# Altium schematic sheet Controller_Sensor_Section.SchDoc
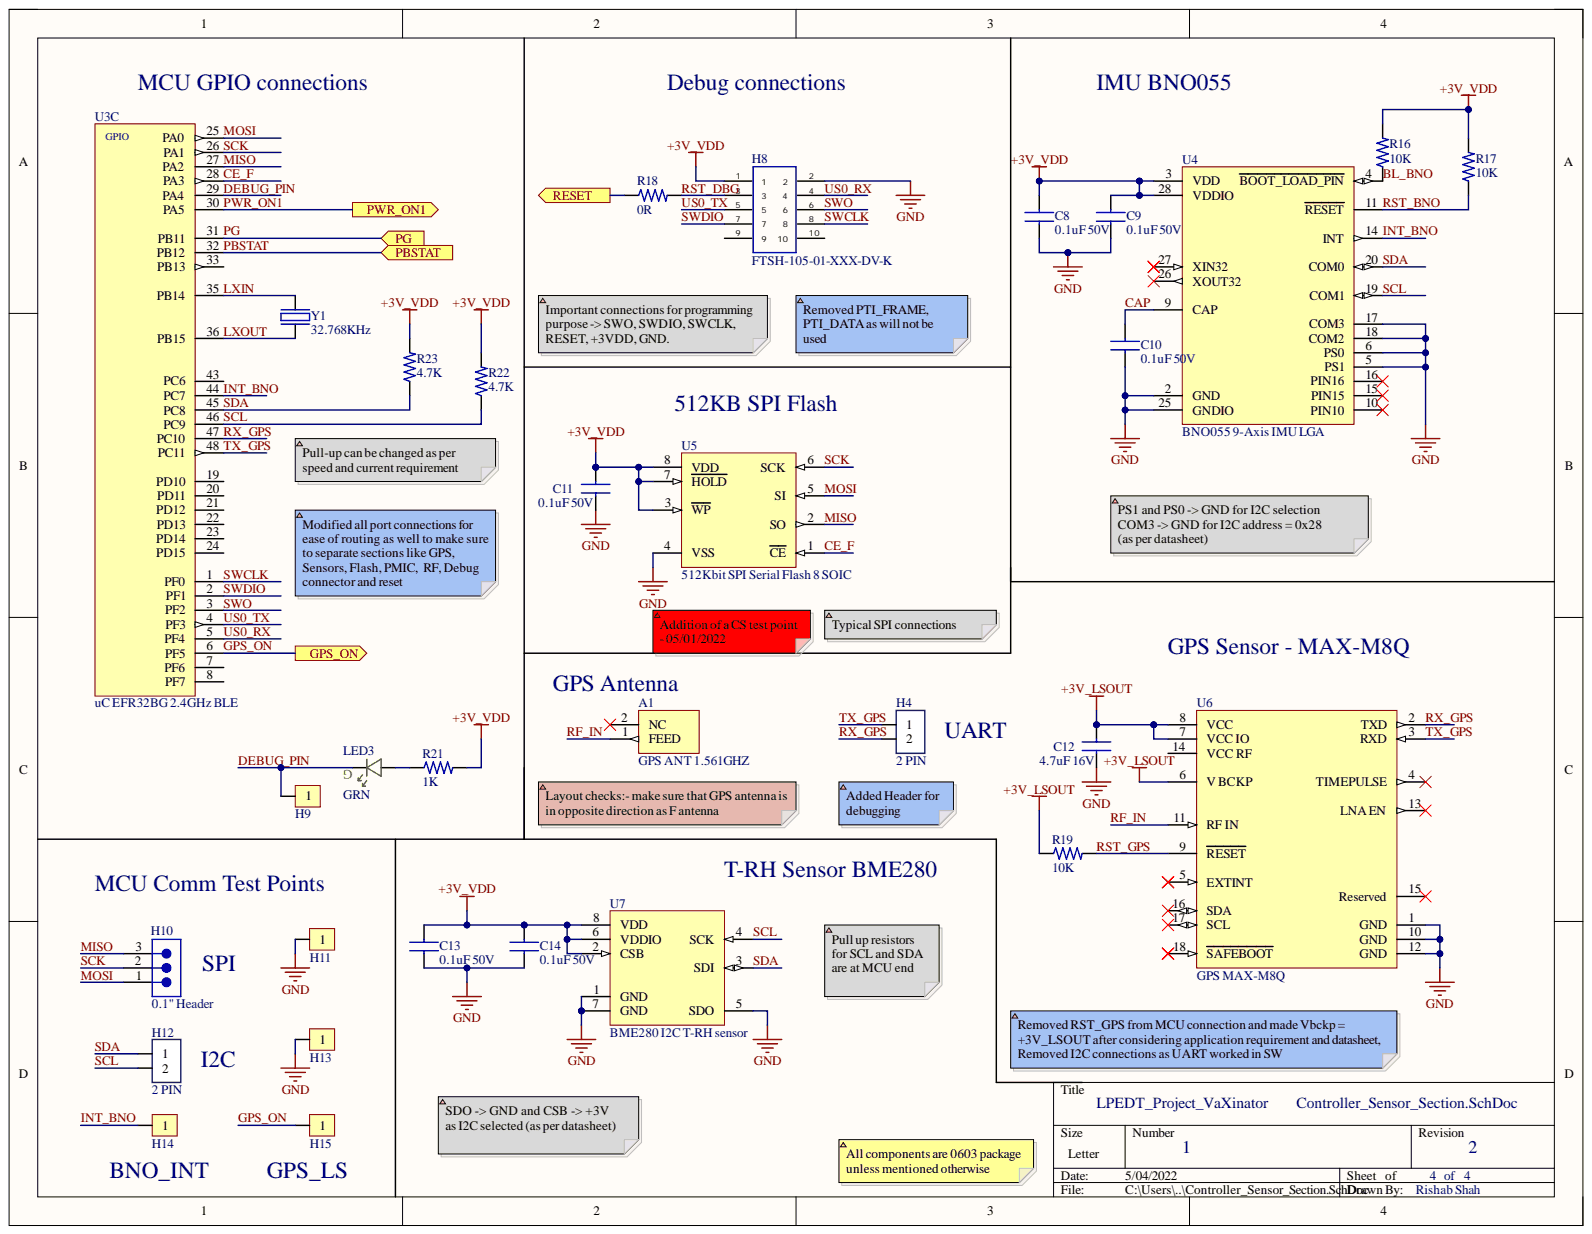
[diagram p0: Controller_Sensor_Section.SchDoc]

## Parts
A1  GPS ANT 1.561GHZ  [GPS_ANT]
C8  0.1uF 50V  [CAP_0603_1608X09N]
C9  0.1uF 50V  Voltage Rating: 50V  [CAP_0603_1608X09N]
C10  0.1uF 50V  [CAP_0603_1608X09N]
C11  0.1uF 50V  Voltage Rating: 50V  [CAP_0603_1608X09N]
C12  4.7uF 16V  Capacitance: 4.7uF  Voltage Rating: 16V  [CAP_0603_1608X09N]
C13  0.1uF 50V  [CAP_0603_1608X09N]
C14  0.1uF 50V  Voltage Rating: 50V  [CAP_0603_1608X09N]
H4  2 PIN  [2_PIN_100MIL]
H8  FTSH-105-01-XXX-DV-K  [FTSH-105-01-XXX-DV-K]
H9  1 pin header  [1_PIN_100MIL]  (value not drawn)
H10  0.1" Header  [3-PIN_MALE_HEADER_01-IN]
H11  1 pin header  [1_PIN_100MIL]  (value not drawn)
H12  2 PIN  [2_PIN_100MIL]
H13  1 pin header  [1_PIN_100MIL]  (value not drawn)
H14  1 pin header  [1_PIN_100MIL]  (value not drawn)
H15  1 pin header  [1_PIN_100MIL]  (value not drawn)
LED3  GRN  [LED_0603_GRN_WL-SMCW]
R16  10K  [RES_0603_1608X06N]
R17  10K  [RES_0603_1608X06N]
R18  0R  [RES_0603_1608X06N]
R19  10K  [RES_0603_1608X06N]
R21  1K  [RES_0603_1608X06N]
R22  4.7K  [RES_0603_1608X06N]
R23  4.7K  [RES_0603_1608X06N]
U3  uC EFR32BG 2.4GHz BLE  [QFN48P700X700X90]  (part C)
U4  BNO055 9-Axis IMU LGA  Case/Package: LGA  [LGA28C50P12X6_520X380X118]
U5  512Kbit SPI Serial Flash 8 SOIC  Case/Package: SOIC  Category: Flash  [8_SOIC_127P600X175]
U6  GPS MAX-M8Q  [u-blox_0_MFG]
U7  BME280 I2C T-RH sensor  [8_LGA_250X250X93_BME280]
Y1  32.768KHz  [XTAL320X150X90]

## Ports
GPS_ON, PBSTAT, PG, PWR_ON1, RESET

## Nets
+3V_LSOUT: C12.1 R19.1 U6.6(V_BCKP) U6.7(VCC_IO) U6.8(VCC)
+3V_VDD: C8.1 C9.2 C11.2 C13.2 C14.2 H8.1 R16.2 R17.2 R21.2 R22.2 R23.2 U4.3(VDD) U4.28(VDDIO) U5.3(WP) U5.7(HOLD) U5.8(VDD) U7.2(CSB) U7.6(VDDIO) U7.8(VDD)
GND: C8.2 C9.1 C10.1 C11.1 C12.2 C13.1 C14.1 H8.2 H11.1 H13.1 U4.2(GND) U4.5(PS1) U4.6(PS0) U4.17(COM3) U4.18(COM2) U4.25(GNDIO) U5.4(VSS) U6.1(GND) U6.10(GND) U6.12(GND) U7.1(GND) U7.5(SDO) U7.7(GND)
BL_BNO: R16.1 U4.4(BOOT_LOAD_PIN)
CAP: C10.2 U4.9(CAP)
CE_F: U3.28(PA3) U5.1(CE)
DEBUG_PIN: H9.1 LED3.C U3.29(PA4)
GPS_ON: H15.1 U3.6(PF5) ; port GPS_ON
INT_BNO: H14.1 U3.44(PC7) U4.14(INT)
LXIN: U3.35(PB14) Y1.2
LXOUT: U3.36(PB15) Y1.1
MISO: H10.3 U3.27(PA2) U5.2(SO)
MOSI: H10.1 U3.25(PA0) U5.5(SI)
PBSTAT: U3.32(PB12) ; port PBSTAT
PG: U3.31(PB11) ; port PG
PWR_ON1: U3.30(PA5) ; port PWR_ON1
RESET: R18.1 ; port RESET
RF_IN: A1.1 U6.11(RF_IN)
RST_BNO: R17.1 U4.11(RESET)
RST_DBG: H8.3 R18.2
RST_GPS: R19.2 U6.9(RESET)
RX_GPS: H4.2 U3.47(PC10) U6.2(TXD)
SCK: H10.2 U3.26(PA1) U5.6(SCK)
SCL: H12.2 R22.1 U3.46(PC9) U4.19(COM1) U7.4(SCK)
SDA: H12.1 R23.1 U3.45(PC8) U4.20(COM0) U7.3(SDI)
SWCLK: H8.8 U3.1(PF0)
SWDIO: H8.7 U3.2(PF1)
SWO: H8.6 U3.3(PF2)
TX_GPS: H4.1 U3.48(PC11) U6.3(RXD)
US0_RX: H8.4 U3.5(PF4)
US0_TX: H8.5 U3.4(PF3)
NetLED3_A: LED3.A R21.1

Not connected: A1 2; H8 9 10; U3 7(PF6) 8(PF7) 19(PD10) 20(PD11) 21(PD12) 22(PD13) 23(PD14) 24(PD15) 33(PB13) 43(PC6); U4 10(PIN10) 15(PIN15) 16(PIN16) 26(XOUT32) 27(XIN32); U6 4(TIMEPULSE) 5(EXTINT) 13(LNA_EN) 14(VCC_RF) 15(Reserved) 16(SDA) 17(SCL) 18(SAFEBOOT)

## Library data of the parts
A1 = 1575AT43A0040E: Antenna 1.561GHz, 1.575GHz, 1.602GHz Beidou, GLONASS, GPS Chip RF Antenna 1.561GHz ~ 1.602GHz 0.5dBi, 1dBi Solder Surface Mount Ceramic 0.5dBi/0.5dBi/1dBi Gain 2-Pin SMD T/R; Manufacturer: Johanson; Bandwidth: 40MHz; Gain: -2.5dB; Impedance: 50R; Max Power Dissipation: 3W; Number of Bands: 1; Return Loss: -9.5dB; Case/Package: SMD/SMT; Category: RF Antennas; Component Kind: Standard; ComponentLink1Description: https://datasheet.ciiva.com/2792/jti-antenna-1575at43a40-2006-09-2792227.pdf?src-supplier=Digi-Key; ComponentLink1URL: https://datasheet.ciiva.com/2792/jti-antenna-1575at43a40-2006-09-2792227.pdf?src-supplier=Digi-Key; ComponentLink2Description: https://datasheet.ciiva.com/4172/antenna-1575at43a0040-4172807.pdf?src-supplier=Arrow+Electronics; ComponentLink2URL: https://datasheet.ciiva.com/4172/antenna-1575at43a0040-4172807.pdf?src-supplier=Arrow+Electronics; ComponentLink3Description: https://datasheet.ciiva.com/24048/antenna-1575at43a0040-258086-24048619.pdf?src-supplier=Mouser; ComponentLink3URL: https://datasheet.ciiva.com/24048/antenna-1575at43a0040-258086-24048619.pdf?src-supplier=Mouser; Frequency: 1.575GHz; Height: 0.031inch; Lead Free: Lead Free; Length: 7mm; Library Name: LPEDT_Project.SchLib; Manufacturer Part Number: 1575AT43A0040E; Max Operating Temperature: 85°C; Min Operating Temperature: -40°C; Mount: Surface Mount; Number of Pins: 2; Package Quantity: 1; Packaging: Tape and Reel; Power Rating: 3W; Pricing 1: 1=0.88000, 10=0.79000, 25=0.75000, 100=0.61590, 250=0.57576, 500=0.50882 (USD); RoHS: No; Series: -; Stock 1: 243; Supplier 1: Digi-Key; Termination: Solder; Width: 2mm
C8-C11 C13 C14 = C0603C104M5RACTU: CAP CER 0.1UF 50V X7R 0603 KEMET; Capacitance: 100nF; Dielectric Material: Ceramic; Dissipation Factor: 2.5%; Tolerance: 20%; Case Code (Imperial): 0603; Case Code (Metric): 1608; Case/Package: 0603; Category: Ceramic Capacitors; Component Kind: Standard; ComponentLink1Description: http://components.arrow.com/part/dataview/40911037S8853915N7429?src-supplier=Arrow+Electronics; ComponentLink1URL: http://components.arrow.com/part/dataview/40911037S8853915N7429?src-supplier=Arrow+Electronics; ComponentLink2Description: https://www.verical.com/server-webapp/html/getDatasheet?partID=626892&src-supplier=Verical; ComponentLink2URL: https://www.verical.com/server-webapp/html/getDatasheet?partID=626892&src-supplier=Verical; ComponentLink3Description: http://www.farnell.com/datasheets/2746056.pdf?src-supplier=Farnell; ComponentLink3URL: http://www.farnell.com/datasheets/2746056.pdf?src-supplier=Farnell; ComponentLink4Description: http://components.arrow.com/part/dataview/0323_00313986?src-supplier=Arrow+Europe; ComponentLink4URL: http://components.arrow.com/part/dataview/0323_00313986?src-supplier=Arrow+Europe; ComponentLink5Description: http://www.mouser.com/ds/2/212/KEM_C1002_X7R_SMD-1102033.pdf?src-supplier=Mouser; ComponentLink5URL: http://www.mouser.com/ds/2/212/KEM_C1002_X7R_SMD-1102033.pdf?src-supplier=Mouser; ComponentLink6Description: http://www.alliedelec.com/m/d/6f3b5eb83d09db50ed28bdc730567b33.pdf?src-supplier=Allied+Electronics; ComponentLink6URL: http://www.alliedelec.com/m/d/6f3b5eb83d09db50ed28bdc730567b33.pdf?src-supplier=Allied+Electronics; ComponentLink7Description: http://www.farnell.com/datasheets/1828800.pdf?src-supplier=Newark; ComponentLink7URL: http://www.farnell.com/datasheets/1828800.pdf?src-supplier=Newark; ComponentLink8Description: http://capacitoredge.kemet.com/capedge2/DataSheet?pn=C0603C104M5RAC7867&src-supplier=Avnet; ComponentLink8URL: http://capacitoredge.kemet.com/capedge2/DataSheet?pn=C0603C104M5RAC7867&src-supplier=Avnet; ComponentLink9Description: http://www.farnell.com/datasheets/2315113.pdf?src-supplier=Newark; ComponentLink9URL: http://www.farnell.com/datasheets/2315113.pdf?src-supplier=Newark; ComponentLink10Description: https://api.kemet.com/component-edge/download/datasheet/C0603C104M5RACTU.pdf?src-supplier=Digi-Key; ComponentLink10URL: https://api.kemet.com/component-edge/download/datasheet/C0603C104M5RACTU.pdf?src-supplier=Digi-Key; ComponentLink11Description: https://www.verical.com/server-webapp/html/getDatasheet?partID=110138&src-supplier=Verical; ComponentLink11URL: https://www.verical.com/server-webapp/html/getDatasheet?partID=110138&src-supplier=Verical; ComponentLink12Description: http://www.farnell.com/datasheets/2746056.pdf?src-supplier=Element14; ComponentLink12URL: http://www.farnell.com/datasheets/2746056.pdf?src-supplier=Element14; ComponentLink13Description: http://www.farnell.com/datasheets/2746056.pdf?src-supplier=Newark; ComponentLink13URL: http://www.farnell.com/datasheets/2746056.pdf?src-supplier=Newark; ComponentLink14Description: http://capacitoredge.kemet.com/capedge2/DataSheet?pn=C0603C104M5RAC7867&src-supplier=Avnet+Asia; ComponentLink14URL: http://capacitoredge.kemet.com/capedge2/DataSheet?pn=C0603C104M5RAC7867&src-supplier=Avnet+Asia; Construction: Flat; Contact Plating: Tin,Matte; Depth: 0.8mm; Dielectric: X7R; Height: 0.8mm; Lead Pitch: 0.7mm; Length: 1.6mm; Library Name: LPEDT_Project_lib.IntLib; Manufacturer: KEMET; Manufacturer Part Number: C0603C104M5RACTU; Max Operating Temperature: 125°C; Min Operating Temperature: -55°C; Mount: Surface Mount,PCB; Package Quantity: 1; Packaging: Tape and Reel; Pricing 1: 1=0.10000, 10=0.03400, 50=0.01840, 100=0.01510, 500=0.01078, 1000=0.00847 (USD); Radiation Hardening: No; REACH SVHC: No SVHC; RoHS: Yes; Series: C; Supplier 1: Digi-Key; Termination: SMD/SMT; Thickness: 0.034inch; Voltage Rating (DC): 50V; Width: 0.031inch
  C8 C10 C13: Voltage Rating: 50V
C12 = GRM188R61C475KE11D: CAP CER 4.7UF 16V X5R 0603 MURATA; Tolerance: 10%; Case Code (Imperial): 0603; Case Code (Metric): 1608; Case/Package: 0603; Category: Ceramic Capacitors; Component Kind: Standard; ComponentLink1Description: http://www.mouser.com/ds/2/281/c02e-2905.pdf?src-supplier=Mouser; ComponentLink1URL: http://www.mouser.com/ds/2/281/c02e-2905.pdf?src-supplier=Mouser; ComponentLink2Description: http://search.murata.co.jp/Ceramy/image/img/A01X/G101/ENG/GRM188R61C475KE11-01.pdf?src-supplier=Digi-Key; ComponentLink2URL: http://search.murata.co.jp/Ceramy/image/img/A01X/G101/ENG/GRM188R61C475KE11-01.pdf?src-supplier=Digi-Key; ComponentLink3Description: http://search.murata.co.jp/Ceramy/image/img/A01X/partnumbering_e_01.pdf?src-supplier=Digi-Key; ComponentLink3URL: http://search.murata.co.jp/Ceramy/image/img/A01X/partnumbering_e_01.pdf?src-supplier=Digi-Key; ComponentLink4Description: http://psearch.en.murata.com/capacitor/product/GRM188R61C475KE11%23.pdf?src-supplier=Avnet; ComponentLink4URL: http://psearch.en.murata.com/capacitor/product/GRM188R61C475KE11%23.pdf?src-supplier=Avnet; Dielectric: X5R; Height: 0.8mm; Lead Free: Lead Free; Length: 0.063inch; Library Name: LPEDT_Project_lib.IntLib; Manufacturer: Murata; Manufacturer Part Number: GRM188R61C475KE11D; Max Operating Temperature: 85°C; Min Operating Temperature: -55°C; Mount: Surface Mount; Package Quantity: 4000; Packaging: Tape and Reel; Pricing 1: 1=0.36000, 10=0.24700, 50=0.17280, 100=0.14820, 500=0.11112, 1000=0.09384 (USD); Resistor Type: Ceramic; RoHS: Yes; RoHS Compliant: Yes; Stock 1: 258482; Supplier 1: Digi-Key; Termination: SMD/SMT; Thickness: 0.037inch; Voltage Rating (DC): 16V; Weight: 0.000222oz; Width: 0.031inch
H4 H12 = J_2_PIN_HEADER: 2.54mm Straight 2 1 Header，Breakaway Through Hole,P=2.54mm Pin Header & Female Header ROHS; MFR Part #: MTP125-1102S1; Component Kind: Standard; Datasheetxx: https://datasheet.lcsc.com/lcsc/1912111437_MINTRON-MTP125-1102S1_C358684.pdf; LCSC Part#: C358684; Library Name: LPEDT_Project_lib.IntLib; Type: Extended; Value: 2 PIN
H8 = FTSH-105-01-L-DV-K: Conn Unshrouded Header HDR 10 POS 1.27mm Solder ST Top Entry SMD Tube; Manufacturer: Samtec; Connector Type: Male Pin; Gender: Male; Max Current Rating: 1.75A; Number of Contacts: 10; Row Spacing: 0.05inch; Category: Headers and Wire Housings; Color: Black; Component Kind: Standard; ComponentLink1Description: https://datasheet.ciiva.com/26936/getdatasheetpartid-175877-26936879.pdf?src-supplier=Verical; ComponentLink1URL: https://datasheet.ciiva.com/26936/getdatasheetpartid-175877-26936879.pdf?src-supplier=Verical; ComponentLink2Description: https://datasheet.ciiva.com/22703/ftsh-smt-22703900.pdf?src-supplier=Element14; ComponentLink2URL: https://datasheet.ciiva.com/22703/ftsh-smt-22703900.pdf?src-supplier=Element14; ComponentLink3Description: https://datasheet.ciiva.com/10245/ftsh-mt-10245272.pdf?src-supplier=Avnet; ComponentLink3URL: https://datasheet.ciiva.com/10245/ftsh-mt-10245272.pdf?src-supplier=Avnet; ComponentLink4Description: https://datasheet.ciiva.com/16657/ftsh-mt-16657372.pdf?src-supplier=Avnet+Europe; ComponentLink4URL: https://datasheet.ciiva.com/16657/ftsh-mt-16657372.pdf?src-supplier=Avnet+Europe; ComponentLink5Description: https://datasheet.ciiva.com/728/ftsh-1xx-xx-xxx-dv-xxx-mkt-728872.pdf?src-supplier=Digi-Key; ComponentLink5URL: https://datasheet.ciiva.com/728/ftsh-1xx-xx-xxx-dv-xxx-mkt-728872.pdf?src-supplier=Digi-Key; Contact Material: Bronze; Contact Mating Length: 0.12inch; Contact Plating: Gold; Contact Type: Male Pin; Depth: 3.43mm; Housing Color: Black; Housing Material: Polymer; Lead Free: Lead Free; Length: 6.35mm; Library Name: LPEDT_Project_lib.IntLib; Manufacturer Part Number: FTSH-105-01-L-DV-K; Max Operating Temperature: 125°C; Min Operating Temperature: -55°C; Mount: Surface Mount; Number of Positions: 10; Number of Rows: 2; Orientation: Straight; Package Quantity: 1; Packaging: Rail/Tube; Pitch: 1.27mm; Pricing 1: 1=4.28000, 10=3.88500, 92=3.42304, 460=2.90954, 1012=2.48168 (USD); Radiation Hardening: No; REACH SVHC: No SVHC; RoHS: Yes; RoHS Compliant: Yes; Series: FTSH; Stock 1: 7428; Supplier 1: Digi-Key; Termination: Solder
H9 H11 H13-H15 = 68000-200HLF: Conn Unshrouded Header HDR 2.54mm Solder ST Top Entry Thru-Hole BergStik® T/R; Manufacturer: Amphenol ICC / FCI; Category: Headers and Wire Housings; Component Kind: Standard; Library Name: LPEDT_Project_lib.IntLib; Manufacturer Part Number: 68000-200HLF; RoHS: No; Supplier 1: Digi-Key
H10 = PRPC003SAAN-RC: CONN HEADER VERT 3POS 2.54MM; Manufacturer: Sullins; Connector Type: Header, Unshrouded, Breakaway; Number of Positions: 3; Number of Positions Loaded: All; Online Catalog: 0.100" (2.54mm) Pitch Connector Headers; Other Names: S9510E-03  S9510E-03-ND; Pitch: 0.100" (2.54mm); Category: Connectors, Interconnects; Color: Black; Component Kind: Standard; ComponentLink1Description: https://media.digikey.com/PDF/Data%20Sheets/Sullins%20PDFs/xRxCzzzSxxN-RC_ST_11635-B.pdf?src-supplier=Digi-Key; ComponentLink1URL: https://media.digikey.com/PDF/Data%20Sheets/Sullins%20PDFs/xRxCzzzSxxN-RC_ST_11635-B.pdf?src-supplier=Digi-Key; Contact Finish: Gold; Contact Finish Thickness: Flash; Contact Mating Length: 0.230" (5.84mm); Contact Type: Male Pin; Family: Rectangular Connectors - Headers, Male Pins; Fastening Type: -; Features: -; Library Name: LPEDT_Project_lib.IntLib; Manufacturer Part Number: PRPC003SAAN-RC; Mounting Type: Through Hole; Number of Rows: 1; Packaging: Bulk; Pricing 1: 1=0.12, 10=0.109, 25=0.0812, 50=0.0696, 100=0.0673, 250=0.058, 500=0.0557 (USD); Row Spacing: -; Series: -; Standard Package: 1; Stock 1: 19067; Supplier 1: Digi-Key; Supplier Part Number 1: S1011EC-03-ND; Termination: Solder
LED3 = 150060GS75000: LED, 0603, GREEN, 430MCD, 520NM; Manufacturer: Wurth Electronics; 3D Model: SMCW_0603.igs    SMCW_0603.stp    SMCW_0603.wrl; Current - Test: 20mA; Dynamic Catalog: 0603 Rectangle with Flat Top Chip LEDs; Lens Style/Size: Rectangle with Flat Top, 1.1mm x 0.7mm; Lens Type: Clear; Other Names: 732-4971-2; Viewing Angle: 140°; Voltage - Forward (Vf) (Typ): 3.2V; Wavelength - Peak: 515nm; Category: Optoelectronics; Color: Green; Component Kind: Standard; ComponentLink1Description: https://katalog.we-online.de/led/datasheet/150060GS75000.pdf?src-supplier=Digi-Key; ComponentLink1URL: https://katalog.we-online.de/led/datasheet/150060GS75000.pdf?src-supplier=Digi-Key; ComponentLink2Description: http://www.produktinfo.conrad.com/datenblaetter/750000-774999/759427-da-01-en-CHIPLED_0603_W_KL_GRUEN_150060GS75000.pdf?src-supplier=Conrad; ComponentLink2URL: http://www.produktinfo.conrad.com/datenblaetter/750000-774999/759427-da-01-en-CHIPLED_0603_W_KL_GRUEN_150060GS75000.pdf?src-supplier=Conrad; Family: LED Indication - Discrete; Height: 0.70mm; Library Name: LPEDT_Project.SchLib; Manufacturer Part Number: 150060GS75000; Millicandela Rating: 430mcd; Mounting Type: Surface Mount; Online Catalog: 0603 Rectangle with Flat Top Chip LEDs; Package / Case: 0603 (1608 Metric); Packaging: Tape & Reel (TR); Pricing 1: 1=0.14, 50=0.129, 100=0.11, 500=0.095 (USD); Series: WL-SMCW; Size / Dimension: 1.60mm L x 0.80mm W; Standard Package: 4,000; Stock 1: 34964; Supplier 1: Digi-Key; Supplier Part Number 1: 732-4971-1-ND; Wavelength - Dominant: 520nm
R16 R17 R19 = RC0603FR-0710KL: Res Thick Film 0603 10K Ohm 1% 1/10W ±100ppm/°C; Manufacturer: Yageo; Max Power Dissipation: 100mW; Resistance: 10kR; Voltage Rating: 75V; Voltage Rating (DC): 50V; Case Code (Imperial): 0603; Case Code (Metric): 1608; Case/Package: 0603; Category: Chip SMD Resistors; Component Kind: Standard; ComponentLink1Description: https://datasheet.ciiva.com/7660/38590-7660789.pdf?src-supplier=Element14; ComponentLink1URL: https://datasheet.ciiva.com/7660/38590-7660789.pdf?src-supplier=Element14; ComponentLink2Description: https://datasheet.ciiva.com/7983/443971-7983596.pdf?src-supplier=Element14; ComponentLink2URL: https://datasheet.ciiva.com/7983/443971-7983596.pdf?src-supplier=Element14; ComponentLink3Description: https://datasheet.ciiva.com/22747/443971-22747519.pdf?src-supplier=Element14; ComponentLink3URL: https://datasheet.ciiva.com/22747/443971-22747519.pdf?src-supplier=Element14; ComponentLink4Description: https://datasheet.ciiva.com/46440/1868787-46440891.pdf?src-supplier=Element14; ComponentLink4URL: https://datasheet.ciiva.com/46440/1868787-46440891.pdf?src-supplier=Element14; ComponentLink5Description: https://datasheet.ciiva.com/7746/1738695-7746239.pdf?src-supplier=Element14; ComponentLink5URL: https://datasheet.ciiva.com/7746/1738695-7746239.pdf?src-supplier=Element14; ComponentLink6Description: https://datasheet.ciiva.com/15189/getdatasheetpartid-409289-15189591.pdf?src-supplier=Verical; ComponentLink6URL: https://datasheet.ciiva.com/15189/getdatasheetpartid-409289-15189591.pdf?src-supplier=Verical; Construction: Rectangular; Depth: 0.8mm; Features: Moisture Resistant; Height: 0.45mm; Lead Free: Lead Free; Lead Pitch: 0.3mm; Length: 1.6mm; Library Name: LPEDT_Project_lib.IntLib; Manufacturer Part Number: RC0603FR-0710KL; Max Operating Temperature: 155°C; Military Standard: Not; Min Operating Temperature: -55°C; Number of Pins: 2; Number of Terminations: 2; Packaging: Tape and Reel; Power Rating: 100mW; Pricing 1: 1=0.10000, 10=0.02400, 100=0.00970, 1000=0.00436, 2500=0.00378 (USD); REACH SVHC: Unknown; Resistance Tolerance: 1%; Resistor Type: Thick Film; RoHS: Yes; RoHS Compliant: Yes; Series: RC; Size Code: 0603; Supplier 1: Digi-Key; Temperature Coefficient: 100ppm/°C; Termination: SMD/SMT; Tolerance: 1%; Weight: 7.1E-05oz; Width: 0.8mm
R18 = RC0603FR-070RL: Res Thick Film 0603 0 OHM JUMPER 1/10W 0603 0Ω ± 1.00% 0.1W 0603; Manufacturer: Yageo; Current Rating: 1A; Max Power Dissipation: 100mW; Resistance: 0mR; Resistance Tolerance: 1%; Temperature Coefficient: 200ppm/°C; Voltage Rating: 50V; Working Voltage: 75V; Case Code (Imperial): 0603; Case Code (Metric): 1608; Case/Package: 0603; Category: Chip SMD Resistors; Component Kind: Standard; ComponentLink1Description: https://datasheet.ciiva.com/11808/0900766b80f96399-11808172.pdf?src-supplier=RSComponents; ComponentLink1URL: https://datasheet.ciiva.com/11808/0900766b80f96399-11808172.pdf?src-supplier=RSComponents; ComponentLink2Description: https://datasheet.ciiva.com/5684/443971-5684699.pdf?src-supplier=Farnell; ComponentLink2URL: https://datasheet.ciiva.com/5684/443971-5684699.pdf?src-supplier=Farnell; ComponentLink3Description: https://datasheet.ciiva.com/7983/443971-7983627.pdf?src-supplier=Element14; ComponentLink3URL: https://datasheet.ciiva.com/7983/443971-7983627.pdf?src-supplier=Element14; ComponentLink4Description: https://datasheet.ciiva.com/22643/1868787-22643388.pdf?src-supplier=Element14; ComponentLink4URL: https://datasheet.ciiva.com/22643/1868787-22643388.pdf?src-supplier=Element14; ComponentLink5Description: https://datasheet.ciiva.com/46382/443971-46382072.pdf?src-supplier=Element14; ComponentLink5URL: https://datasheet.ciiva.com/46382/443971-46382072.pdf?src-supplier=Element14; ComponentLink6Description: https://datasheet.ciiva.com/29721/pyu-r-int-thick-7-29721178.pdf?src-supplier=Digi-Key; ComponentLink6URL: https://datasheet.ciiva.com/29721/pyu-r-int-thick-7-29721178.pdf?src-supplier=Digi-Key; ComponentLink7Description: https://datasheet.ciiva.com/29721/pyu-rc0603-51-rohs-l-4-29721179.pdf?src-supplier=Digi-Key; ComponentLink7URL: https://datasheet.ciiva.com/29721/pyu-rc0603-51-rohs-l-4-29721179.pdf?src-supplier=Digi-Key; ComponentLink8Description: https://datasheet.ciiva.com/5558/rc0603-272842-5558341.pdf?src-supplier=Mouser; ComponentLink8URL: https://datasheet.ciiva.com/5558/rc0603-272842-5558341.pdf?src-supplier=Mouser; Construction: Rectangular; Contact Plating: Tin,Matte; Depth: 0.8mm; Features: Moisture Resistant; Height: 0.45mm; Lead Free: Lead Free; Length: 1.6mm; Library Name: LPEDT_Project_lib.IntLib; Manufacturer Part Number: RC0603FR-070RL; Max Operating Temperature: 155°C; Military Standard: Not; Min Operating Temperature: -55°C; Number of Pins: 2; Number of Terminations: 2; Package Quantity: 5000; Packaging: Tape and Reel; Power Rating: 100mW; Pricing 1: 1=0.10000, 10=0.01800, 25=0.01280, 50=0.00980, 100=0.00730, 250=0.00552, 500=0.00442, 1000=0.00326 (USD); Radiation Hardening: No; REACH SVHC: No SVHC; Resistor Type: Thick Film; RoHS: Yes; RoHS Compliant: Yes; Series: RC; Stock 1: 133608; Supplier 1: Digi-Key; Technology: THICK FILM; Termination: SMD/SMT; Tolerance: 1%; Voltage Rating (DC): 50V; Width: 0.031inch
R21 = RC0603FR-071KL: RES 1KΩ ± 1.00% 0.1W ± 200ppm/℃ 0603; Manufacturer: Yageo; Max Power Dissipation: 100mW; Resistance: 1kR; Resistance Tolerance: 1%; Temperature Coefficient: 100ppm/°C; Voltage Rating: 50V; Case Code (Imperial): 0603; Case Code (Metric): 1608; Case/Package: 0603; Category: Chip SMD Resistors; Component Kind: Standard; ComponentLink1Description: https://datasheet.ciiva.com/7746/1686128-7746280.pdf?src-supplier=Element14; ComponentLink1URL: https://datasheet.ciiva.com/7746/1686128-7746280.pdf?src-supplier=Element14; ComponentLink2Description: https://datasheet.ciiva.com/7660/1868787-7660790.pdf?src-supplier=Element14; ComponentLink2URL: https://datasheet.ciiva.com/7660/1868787-7660790.pdf?src-supplier=Element14; Construction: Rectangular; Contact Plating: Tin,Matte; Depth: 0.8mm; Features: Moisture Resistant; Height: 0.45mm; Lead Free: Lead Free; Length: 1.6mm; Library Name: LPEDT_Project_lib.IntLib; Manufacturer Part Number: RC0603FR-071KL; Max Operating Temperature: 155°C; Military Standard: Not; Min Operating Temperature: -55°C; Number of Terminations: 2; Packaging: Tape and Reel; Power Rating: 100mW; Pricing 1: 5000=0.00312 (USD); Radiation Hardening: No; REACH SVHC: No SVHC; Resistor Type: Thick Film; RoHS: Yes; RoHS Compliant: Yes; Series: RC; Size Code: 0603; Stock 1: 9007788; Supplier 1: Digi-Key; Technology: THICK FILM; Termination: SMD/SMT; Tolerance: 1%
R22 R23 = RC0603FR-074K7L: Res Thick Film 0603 4.7K OHM 1% 1/10W 0603 4700Ω ± 1.00% 0.1W ± 100ppm/℃ 0603; Manufacturer: Yageo; Max Power Dissipation: 100mW; Resistance: 4.7kR; Temperature Coefficient: 100ppm/°C; Voltage Rating: 50V; Case Code (Imperial): 0603; Case Code (Metric): 1608; Case/Package: 0603; Category: Chip SMD Resistors; Component Kind: Standard; ComponentLink1Description: https://datasheet.ciiva.com/15189/getdatasheetpartid-422754-15189760.pdf?src-supplier=Verical; ComponentLink1URL: https://datasheet.ciiva.com/15189/getdatasheetpartid-422754-15189760.pdf?src-supplier=Verical; ComponentLink2Description: https://datasheet.ciiva.com/7660/38590-7660794.pdf?src-supplier=Element14; ComponentLink2URL: https://datasheet.ciiva.com/7660/38590-7660794.pdf?src-supplier=Element14; ComponentLink3Description: https://datasheet.ciiva.com/22747/443971-22747528.pdf?src-supplier=Element14; ComponentLink3URL: https://datasheet.ciiva.com/22747/443971-22747528.pdf?src-supplier=Element14; ComponentLink4Description: https://datasheet.ciiva.com/46440/1868787-46440909.pdf?src-supplier=Element14; ComponentLink4URL: https://datasheet.ciiva.com/46440/1868787-46440909.pdf?src-supplier=Element14; ComponentLink5Description: https://datasheet.ciiva.com/7746/1868787-7746365.pdf?src-supplier=Element14; ComponentLink5URL: https://datasheet.ciiva.com/7746/1868787-7746365.pdf?src-supplier=Element14; ComponentLink6Description: https://datasheet.ciiva.com/7746/1738695-7746366.pdf?src-supplier=Element14; ComponentLink6URL: https://datasheet.ciiva.com/7746/1738695-7746366.pdf?src-supplier=Element14; Construction: Rectangular; Depth: 0.8mm; Features: Moisture Resistant; Height: 0.45mm; Lead Free: Lead Free; Lead Pitch: 0.3mm; Length: 1.6mm; Library Name: LPEDT_Project_lib.IntLib; Manufacturer Part Number: RC0603FR-074K7L; Max Operating Temperature: 155°C; Military Standard: Not; Min Operating Temperature: -55°C; Number of Pins: 2; Number of Terminations: 2; Packaging: Tape and Reel; Power Rating: 100mW; Pricing 1: 1=0.10000, 10=0.02400, 100=0.00970, 1000=0.00436, 2500=0.00378 (USD); REACH SVHC: No SVHC; Resistance Tolerance: 1%; Resistor Type: Thick Film; RoHS: Yes; RoHS Compliant: Yes; Series: RC; Size Code: 0603; Stock 1: 25; Supplier 1: Digi-Key; Termination: SMD/SMT; Tolerance: 1%; Voltage Rating (DC): 50V; Width: 0.8mm
U3 = EFR32BG13P732F512GM48-D: Blue Gecko QFN48 2.4 G 19 dB BLE/Proprietary 512 kB 64 kB(RAM) 31GPIO; Manufacturer: Silicon Labs; Category: RF Receivers, Transceivers; Component Kind: Standard; ComponentLink1Description: http://www.farnell.com/datasheets/2813683.pdf?src-supplier=Farnell; ComponentLink1URL: http://www.farnell.com/datasheets/2813683.pdf?src-supplier=Farnell; Library Name: LPEDT_Project.SchLib; Manufacturer Part Number: EFR32BG13P732F512GM48-D; Pricing 1: 1=8.42000, 10=7.72000, 25=7.01800, 80=6.31625, 230=5.78987, 440=5.26350, 945=4.78499 (USD); RoHS: Yes; Supplier 1: Digi-Key
U4 = BNO055: IMU BNO055 Series 1.7 to 3.6 V Intelligent 9-Axis Absolute Orientation Sensor-LGA-28; Manufacturer: Bosch; Output Type: I2C; Sensor Type: Accelerometer,Gyroscope,Magnetometer,3 Axis; Category: Inertial Measurement Units (IMUs); Component Kind: Standard; ComponentLink1Description: https://ae-bst.resource.bosch.com/media/_tech/media/application_notes/BST-BNO055-HS000.pdf ?src-supplier=Digi-Key; ComponentLink1URL: https://ae-bst.resource.bosch.com/media/_tech/media/application_notes/BST-BNO055-HS000.pdf ?src-supplier=Digi-Key; Lead Free: Lead Free; Library Name: LPEDT_Project.SchLib; Manufacturer Part Number: BNO055; Max Operating Temperature: 85°C; Min Operating Temperature: -40°C; Mount: Surface Mount; Packaging: Tape and Reel; RoHS: No; Supplier 1: Future Electronics
U5 = SST25VF512A-33-4C-SAE-T: 512Kbit SPI Serial Flash 8 SOIC 2.7V to 3.6V 3.90mm(.150in) T/R RoHS Compliant: Yes; Manufacturer: Microchip; Access Time: 12ns; Address Bus Width: 16b; Data Bus Width: 8b; Density: 512Kb; Frequency: 33MHz; Interface: SPI,Serial; Memory Type: FLASH,NOR,NAND; Nominal Supply Current: 10mA; Number of Words: 64000; Operating Supply Voltage: 3.3V; Sync/Async: Synchronous; Access Time-Max: 12ns; Component Kind: Standard; ComponentLink1Description: https://datasheet.ciiva.com/4868/40506-5200-4868130.pdf?src-supplier=Mouser; ComponentLink1URL: https://datasheet.ciiva.com/4868/40506-5200-4868130.pdf?src-supplier=Mouser; ComponentLink2Description: https://datasheet.ciiva.com/15126/getdatasheetpartid-762348-15126747.pdf?src-supplier=Verical; ComponentLink2URL: https://datasheet.ciiva.com/15126/getdatasheetpartid-762348-15126747.pdf?src-supplier=Verical; ComponentLink3Description: https://datasheet.ciiva.com/9278/25090a-9278797.pdf?src-supplier=Avnet; ComponentLink3URL: https://datasheet.ciiva.com/9278/25090a-9278797.pdf?src-supplier=Avnet; ComponentLink4Description: https://datasheet.ciiva.com/1909/filehandler-aspxddocname-en550389-1909889.pdf?src-supplier=Digi-Key; ComponentLink4URL: https://datasheet.ciiva.com/1909/filehandler-aspxddocname-en550389-1909889.pdf?src-supplier=Digi-Key; Lead Free: Lead Free; Library Name: LPEDT_Project.SchLib; Manufacturer Part Number: SST25VF512A-33-4C-SAE-T; Max Frequency: 33MHz; Max Operating Temperature: 70°C; Max Supply Voltage: 3.6V; Memory Size: 512Kb; Min Operating Temperature: 0°C; Min Supply Voltage: 2.7V; Number of Pins: 8; Package Quantity: 3300; Packaging: Tape and Reel; Pricing 1: 1=0.58000, 25=0.56200, 100=0.54060 (USD); Radiation Hardening: No; RoHS: Yes; RoHS Compliant: Yes; Series: SST25; Stock 1: 2282; Supplier 1: Digi-Key; Supply Current: 10mA; Word Size: 8b
U6 = MAX-M8Q-0-10: GPS/GlONASS/QZSS Cellular Module Automotive | RF RCVR GNSS/GPS 1.575GHZ 18LCC; Manufacturer: u-blox; Category: RF Receivers, Transceivers; Component Kind: Standard; Library Name: LPEDT_Project.SchLib; Manufacturer Part Number: MAX-M8Q-0-10; RoHS: Yes; RoHS Compliant: Yes; Supplier 1: Digi-Key
U7 = BME280: TRH Sensor Digital Output 1.8V 8-Pin LGA T/R; Manufacturer: Bosch; Accuracy: 3%; Output Type: SPI,I2C; Response Time: 1s; Sensor Type: Temperature; Case/Package: LGA; Category: Pressure Sensors; Component Kind: Standard; ComponentLink1Description: https://ae-bst.resource.bosch.com/media/_tech/media/datasheets/BST-BME280-DS002.pdf?src-supplier=Digi-Key; ComponentLink1URL: https://ae-bst.resource.bosch.com/media/_tech/media/datasheets/BST-BME280-DS002.pdf?src-supplier=Digi-Key; ComponentLink2Description: https://ae-bst.resource.bosch.com/media/_tech/media/product_flyer/BME280_Productflyer_BST_20170109.pdf?src-supplier=Arrow+Electronics; ComponentLink2URL: https://ae-bst.resource.bosch.com/media/_tech/media/product_flyer/BME280_Productflyer_BST_20170109.pdf?src-supplier=Arrow+Electronics; ComponentLink3Description: https://ae-bst.resource.bosch.com/media/_tech/media/product_flyer/BME280_Productflyer_BST_20170109.pdf?src-supplier=Mouser; ComponentLink3URL: https://ae-bst.resource.bosch.com/media/_tech/media/product_flyer/BME280_Productflyer_BST_20170109.pdf?src-supplier=Mouser; ComponentLink4Description: https://ae-bst.resource.bosch.com/media/_tech/media/product_flyer/BME280_Productflyer_BST_20170109.pdf?src-supplier=NAC+Semi; ComponentLink4URL: https://ae-bst.resource.bosch.com/media/_tech/media/product_flyer/BME280_Productflyer_BST_20170109.pdf?src-supplier=NAC+Semi; Lead Free: Lead Free; Library Name: LPEDT_Project.SchLib; Manufacturer Part Number: BME280; Max Operating Temperature: 85°C; Min Operating Temperature: -40°C; Mount: Surface Mount; Number of Pins: 8; Packaging: Cut Tape; RoHS: No; Supplier 1: Future Electronics
Y1 = FC-13532.7680KA-AG3: Crystal 32768Hz ±20ppm (Tol) 7pF FUND 70000Ohm 2-Pin CSMD T/R; Manufacturer: Epson; Drive Level: 0.5uW; ESR (Equivalent Series Resistance): 70kR; Case/Package: SMD/SMT; Category: Crystals; Component Kind: Standard; ComponentLink1Description: http://components.arrow.com/part/dataview/46871488S8345155N1318?src-supplier=Arrow+Electronics; ComponentLink1URL: http://components.arrow.com/part/dataview/46871488S8345155N1318?src-supplier=Arrow+Electronics; ComponentLink2Description: http://www.mouser.com/ds/2/137/C-135R_en-1109071.pdf?src-supplier=Mouser; ComponentLink2URL: http://www.mouser.com/ds/2/137/C-135R_en-1109071.pdf?src-supplier=Mouser; ComponentLink3Description: https://support.epson.biz/td/api/doc_check.php?dl=brief_FC-135R_en.pdf&src-supplier=Digi-Key; ComponentLink3URL: https://support.epson.biz/td/api/doc_check.php?dl=brief_FC-135R_en.pdf&src-supplier=Digi-Key; ComponentLink4Description: https://support.epson.biz/td/api/doc_check.php?dl=brief_FC-135&lang=en&src-supplier=Digi-Key; ComponentLink4URL: https://support.epson.biz/td/api/doc_check.php?dl=brief_FC-135&lang=en&src-supplier=Digi-Key; ComponentLink5Description: https://www.verical.com/server-webapp/html/getDatasheet?partID=306660&src-supplier=Verical; ComponentLink5URL: https://www.verical.com/server-webapp/html/getDatasheet?partID=306660&src-supplier=Verical; Depth: 1.5mm; Frequency: 32.768kHz; Frequency Stability: 20ppm; Frequency Tolerance: 20ppm; Height: 0.035inch; Length: 3.2mm; Library Name: LPEDT_Project.SchLib; Load Capacitance: 7pF; Manufacturer Part Number: FC-13532.7680KA-AG3; Max Operating Temperature: 85°C; Min Operating Temperature: -40°C; Mount: Surface Mount; Number of Pins: 2; Package Quantity: 250; Packaging: Tape and Reel; Pricing 1: 1=0.73000, 10=0.60500, 50=0.54440, 100=0.48400 (USD); RoHS: Yes; RoHS Compliant: Yes; Series: FC-135; Stock 1: 101; Supplier 1: Digi-Key; Termination: SMD/SMT; Tolerance: 20ppm; Weight: 0.000564oz; Width: 0.061inch
